# Altium schematic sheet Trex.SchDoc(Trex)
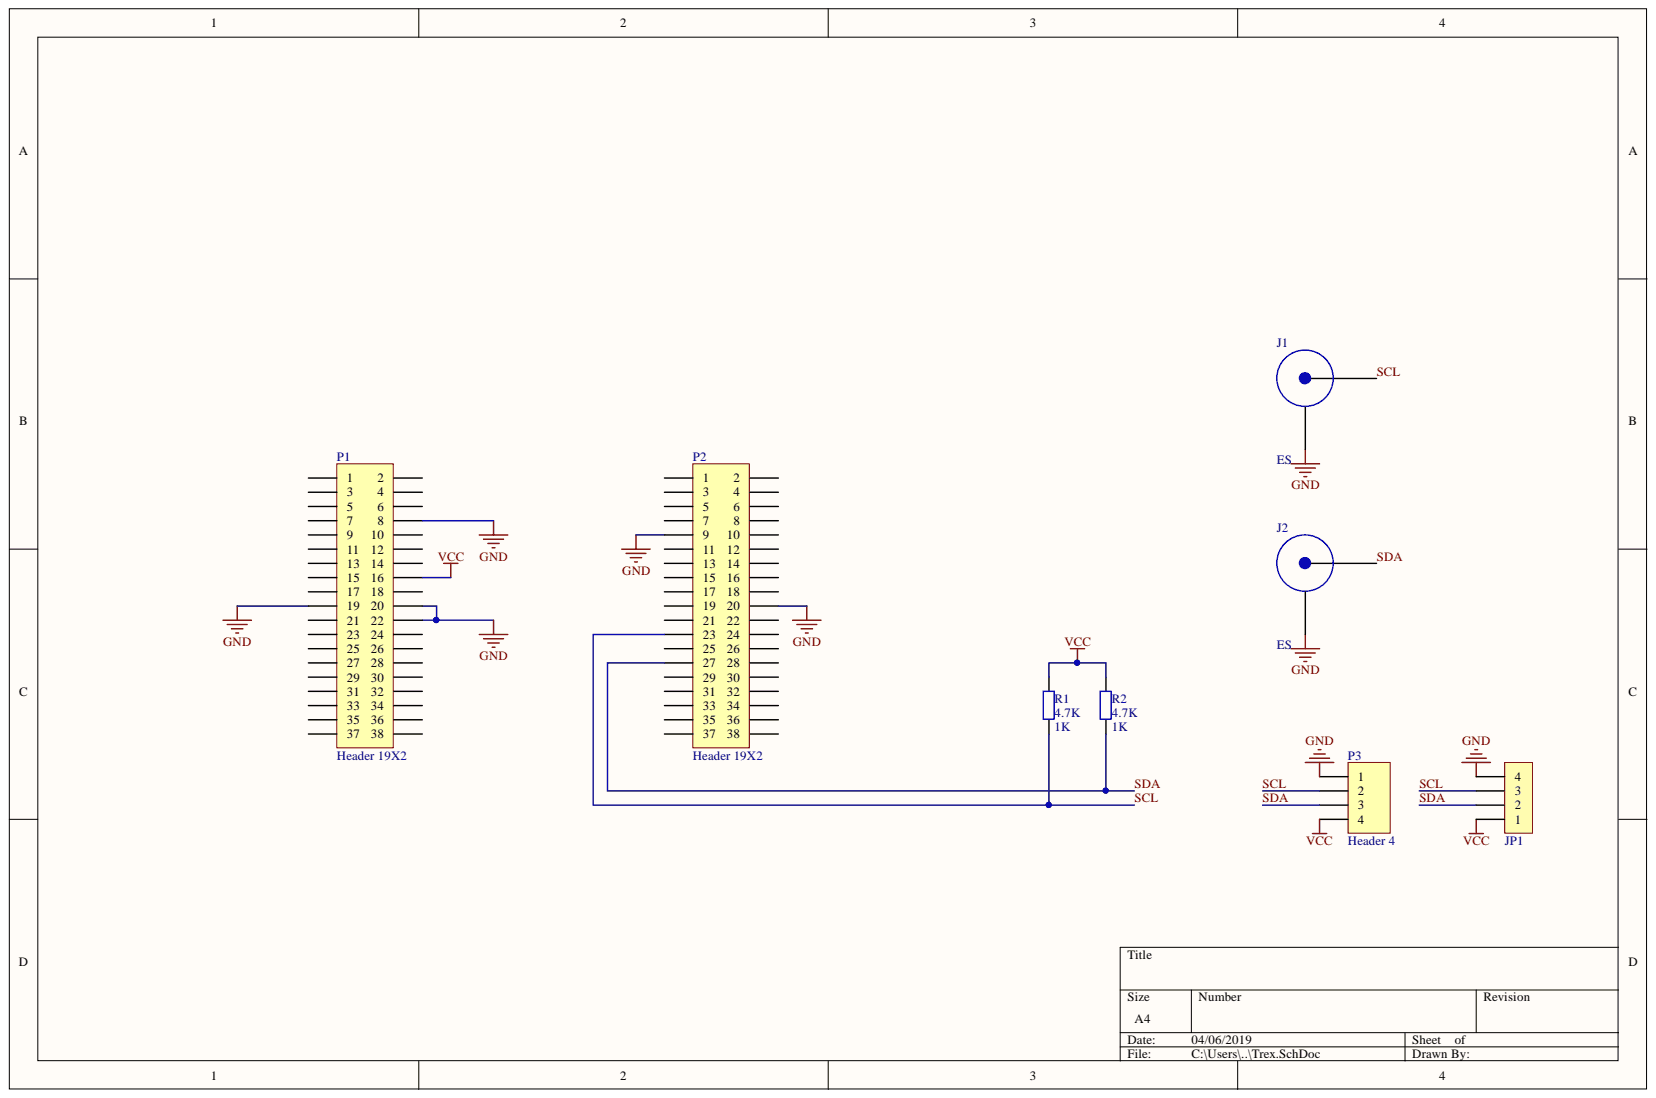
[diagram p0: Trex.SchDoc]

## Parts
J1  ES  [BNC]
J2  ES  [BNC]
JP1  Connecteur Autocom 4pts  [Autocom HE14 - 4 - Coudé]  (value not drawn)
P1  Header 19X2  [HDR2X19]
P2  Header 19X2  [HDR2X19]
P3  Header 4  [HDR1X4]
R1  4.7K  value 1K  [AXIAL-0.4]
R2  4.7K  value 1K  [AXIAL-0.4]

## Nets
GND: J1.2 J2.2 JP1.4 P1.8 P1.19 P1.20 P1.22 P2.9 P2.20 P3.1
VCC: JP1.1 P1.16 P3.4 R1.2 R2.2
SCL: J1.1 JP1.3 P2.23 P3.2 R1.1
SDA: J2.1 JP1.2 P2.27 P3.3 R2.1

Not connected: P1 1 2 3 4 5 6 7 9 10 11 12 13 14 15 17 18 21 23 24 25 26 27 28 29 30 31 32 33 34 35 36 37 38; P2 1 2 3 4 5 6 7 8 10 11 12 13 14 15 16 17 18 19 21 22 24 25 26 28 29 30 31 32 33 34 35 36 37 38

## Library data of the parts
J1 J2 = BNC: Component Kind: Standard; Library Name: Gamel_Trophy_v4re1.IntLib
JP1 = Connecteur Autocom 4 pts: connecteur autocom HE14 4 pts; Manufacturer: TE CONNECTIVITY / AMP; Manufacturer Part Number: 281698-4; Component Kind: Standard; ComponentLink1Description: http://www.tycoelectronics.com/commerce/DocumentDelivery/DDEController?Action=srchrtrv&DocNm=CVM_281698-4&DocType=Customer+View+Model&DocLang=3D; ComponentLink1URL: http://www.tycoelectronics.com/commerce/DocumentDelivery/DDEController?Action=srchrtrv&DocNm=CVM_281698-4&DocType=Customer+View+Model&DocLang=3D; ComponentLink2Description: http://www.tycoelectronics.com/commerce/DocumentDelivery/DDEController?Action=srchrtrv&DocNm=281698&DocType=Customer+Drawing&DocLang=English; ComponentLink2URL: http://www.tycoelectronics.com/commerce/DocumentDelivery/DDEController?Action=srchrtrv&DocNm=281698&DocType=Customer+Drawing&DocLang=English; ComponentLink3Description: http://www.tycoelectronics.com/commerce/DocumentDelivery/DDEController?Action=srchrtrv&DocNm=108-15053&DocType=Specification+Or+Standard&DocLang=French; ComponentLink3URL: http://www.tycoelectronics.com/commerce/DocumentDelivery/DDEController?Action=srchrtrv&DocNm=108-15053&DocType=Specification+Or+Standard&DocLang=French; ComponentLink4Description: http://www.farnell.com/datasheets/23840.pdf; ComponentLink4URL: http://www.farnell.com/datasheets/23840.pdf; Pricing 1: 5=0,55, 100=0,53, 250=0,45 (EUR); RoHS: YES; Stock 1: 5967; Supplier 1: Farnell; Supplier Part Number 1: 1205992; Library Name: GEII.IntLib
P1 P2 = Header 19X2: Header, 19-Pin, Dual row; Component Kind: Standard; LatestRevisionDate: 17-Jul-2002; LatestRevisionNote: Re-released for DXP Platform.; Library Name: Miscellaneous Connectors.IntLib; Signal Integrity: Connector; Publisher: Altium Limited
P3 = Header 4: Header, 4-Pin; Component Kind: Standard; LatestRevisionDate: 17-Jul-2002; LatestRevisionNote: Re-released for DXP Platform.; Library Name: Miscellaneous Connectors.IntLib; Signal Integrity: Connector; Publisher: Altium Limited
R1 R2 = Res2: Resistor; Component Kind: Standard; LatestRevisionDate: 17-Jul-2002; LatestRevisionNote: Re-released for DXP Platform.; Library Name: Miscellaneous Devices.IntLib; PackageDescription: Axial Device, Thru-Hole; 2 Leads; 0.4 in Pin Spacing; PackageReference: AXIAL-0.4; Published: 8-Jun-2000; Signal Integrity: Res; Simulation: RESISTOR; Publisher: Altium Limited
